# Altium schematic sheet SDRAM.SchDoc(SDRAM)
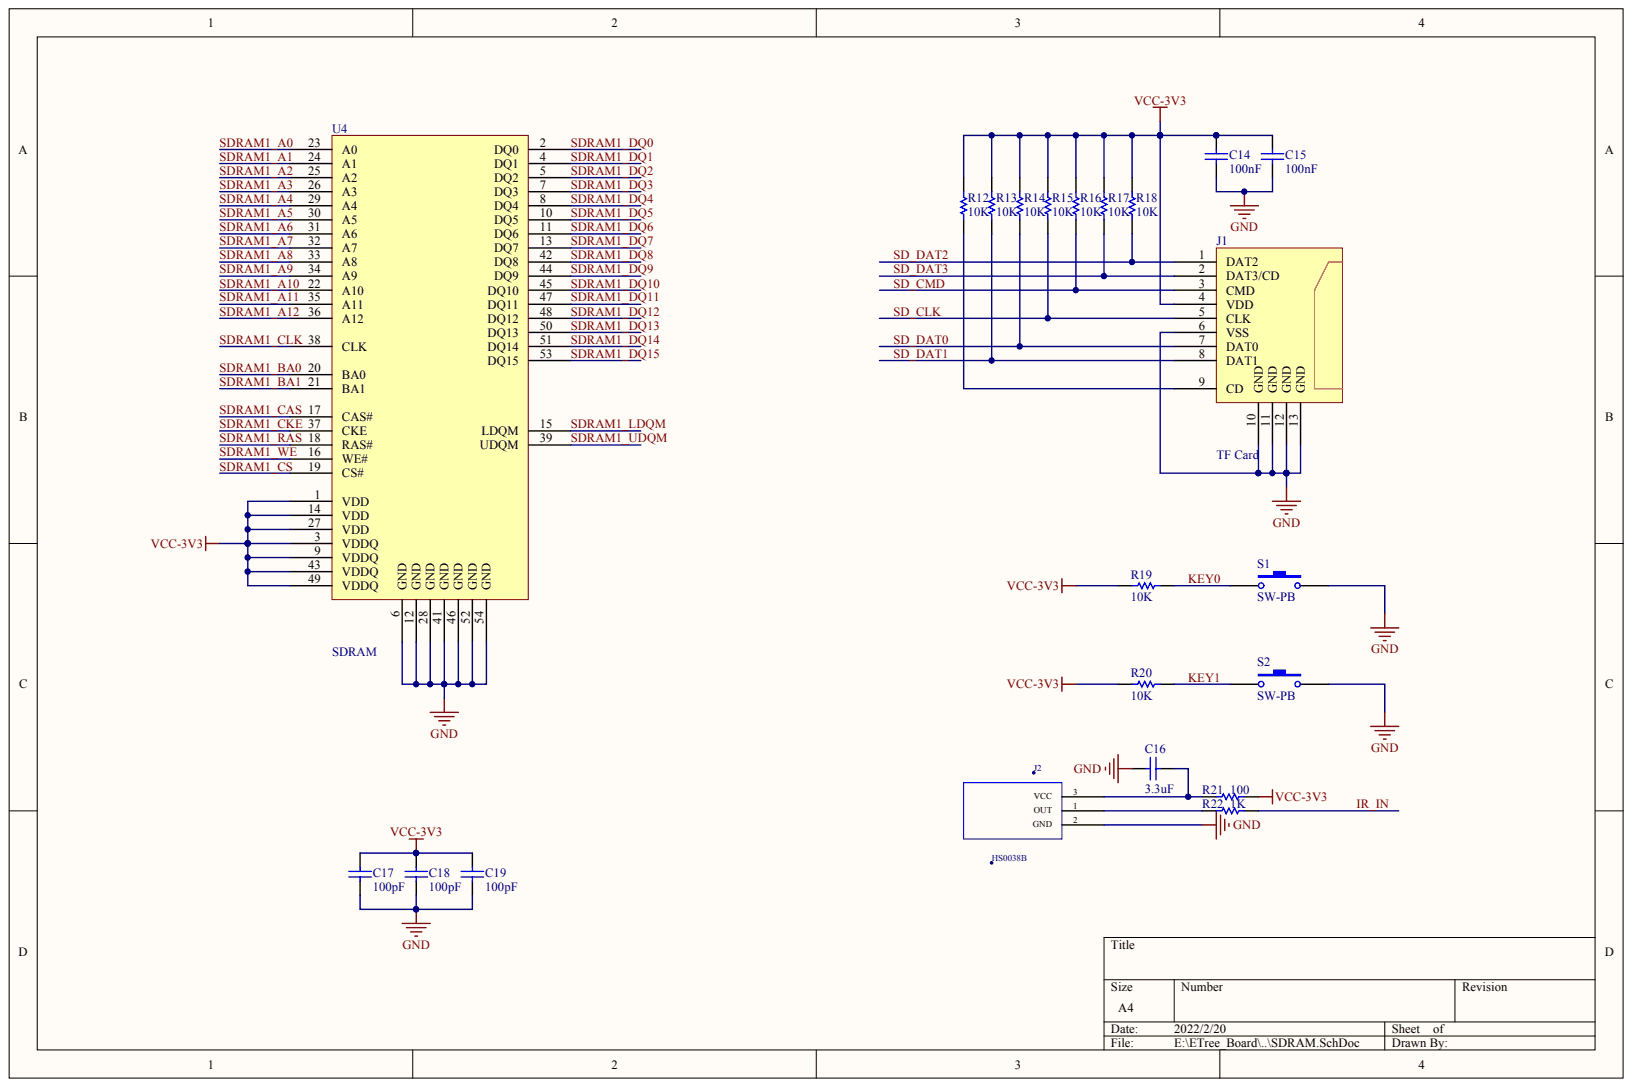
[diagram p0: SDRAM.SchDoc]

## Parts
C14  value 100nF  (hidden comment: Cap)
C15  value 100nF  (hidden comment: Cap)
C16  value 3.3uF  (hidden comment: Cap)
C17  value 100pF  (hidden comment: Cap)
C18  value 100pF  (hidden comment: Cap)
C19  value 100pF  (hidden comment: Cap)
J1  TF Card
J2  value HS0038B  (hidden comment: DHT11_DS18B20_1)
R12  value 10K  (hidden comment: Res1)
R13  value 10K  (hidden comment: Res1)
R14  value 10K  (hidden comment: Res1)
R15  value 10K  (hidden comment: Res1)
R16  value 10K  (hidden comment: Res1)
R17  value 10K  (hidden comment: Res1)
R18  value 10K  (hidden comment: Res1)
R19  value 10K  (hidden comment: Res1)
R20  value 10K  (hidden comment: Res1)
R21  value 100  (hidden comment: Res1)
R22  value 1K  (hidden comment: Res1)
S1  SW-PB
S2  SW-PB
U4  SDRAM

## Nets
GND: C14.2 C15.1 C16.2 C17.1 C18.1 C19.1 J1.6(VSS) J1.10(GND) J1.11(GND) J1.12(GND) J1.13(GND) J2.2 S1.2 S2.2 U4.6(GND) U4.12(GND) U4.28(GND) U4.41(GND) U4.46(GND) U4.52(GND) U4.54(GND)
VCC-3V3: C14.1 C15.2 C17.2 C18.2 C19.2 J1.4(VDD) R12.2 R13.2 R14.2 R15.2 R16.2 R17.2 R18.2 R19.2 R20.2 R21.1 U4.1(VDD) U4.3(VDDQ) U4.9(VDDQ) U4.14(VDD) U4.27(VDD) U4.43(VDDQ) U4.49(VDDQ)
IR_IN: R22.1
KEY0: R19.1 S1.1
KEY1: R20.1 S2.1
SD_CLK: J1.5(CLK) R15.1
SD_CMD: J1.3(CMD) R16.1
SD_DAT0: J1.7(DAT0) R14.1
SD_DAT1: J1.8(DAT1) R13.1
SD_DAT2: J1.1(DAT2) R18.1
SD_DAT3: J1.2(DAT3/CD) R17.1
SDRAM1_A0: U4.23(A0)
SDRAM1_A1: U4.24(A1)
SDRAM1_A2: U4.25(A2)
SDRAM1_A3: U4.26(A3)
SDRAM1_A4: U4.29(A4)
SDRAM1_A5: U4.30(A5)
SDRAM1_A6: U4.31(A6)
SDRAM1_A7: U4.32(A7)
SDRAM1_A8: U4.33(A8)
SDRAM1_A9: U4.34(A9)
SDRAM1_A10: U4.22(A10)
SDRAM1_A11: U4.35(A11)
SDRAM1_A12: U4.36(A12)
SDRAM1_BA0: U4.20(BA0)
SDRAM1_BA1: U4.21(BA1)
SDRAM1_CAS: U4.17(CAS#)
SDRAM1_CKE: U4.37(CKE)
SDRAM1_CLK: U4.38(CLK)
SDRAM1_CS: U4.19(CS#)
SDRAM1_DQ0: U4.2(DQ0)
SDRAM1_DQ1: U4.4(DQ1)
SDRAM1_DQ2: U4.5(DQ2)
SDRAM1_DQ3: U4.7(DQ3)
SDRAM1_DQ4: U4.8(DQ4)
SDRAM1_DQ5: U4.10(DQ5)
SDRAM1_DQ6: U4.11(DQ6)
SDRAM1_DQ7: U4.13(DQ7)
SDRAM1_DQ8: U4.42(DQ8)
SDRAM1_DQ9: U4.44(DQ9)
SDRAM1_DQ10: U4.45(DQ10)
SDRAM1_DQ11: U4.47(DQ11)
SDRAM1_DQ12: U4.48(DQ12)
SDRAM1_DQ13: U4.50(DQ13)
SDRAM1_DQ14: U4.51(DQ14)
SDRAM1_DQ15: U4.53(DQ15)
SDRAM1_LDQM: U4.15(LDQM)
SDRAM1_RAS: U4.18(RAS#)
SDRAM1_UDQM: U4.39(UDQM)
SDRAM1_WE: U4.16(WE#)
NetC16_1: C16.1 J2.3 R21.2
NetJ1_9: J1.9(CD) R12.1
NetJ2_1: J2.1 R22.2

## Library data of the parts
J2 = (no symbol name): PCB DECAL: SIP3-2P54; Source_Path: D:\NXP_RT1050\RT1050_MB_PRO\SCH\IMXRT1052_MB_PRO_0413.DSN
C14-C19 J1 R12-R22 S1 S2 U4 = (no symbol name)
  C14-C19: Published: 8-Jun-2000; LatestRevisionDate: 17-Jul-2002; LatestRevisionNote: Re-released for DXP Platform.; PackageReference: RAD-0.3; PackageDescription: Radial Cap, Thru-Hole; 2 Leads; 0.3 in Pin Spacing
  R12-R22: Published: 8-Jun-2000; LatestRevisionDate: 17-Jul-2002; LatestRevisionNote: Re-released for DXP Platform.; PackageReference: AXIAL-0.3; PackageDescription: Axial Device, Thru-Hole; 2 Leads; 0.3 in Pin Spacing
  S1 S2: Published: 24-Mar-1999; LatestRevisionDate: 17-Jul-2002; LatestRevisionNote: Re-released for DXP Platform.; PackageReference: SPST-2; PackageDescription: Switch; 2 Leads
  C14-C19 R12-R22 S1 S2: Publisher: Altium Limited
